# Altium schematic sheet ESP-TX.SchDoc
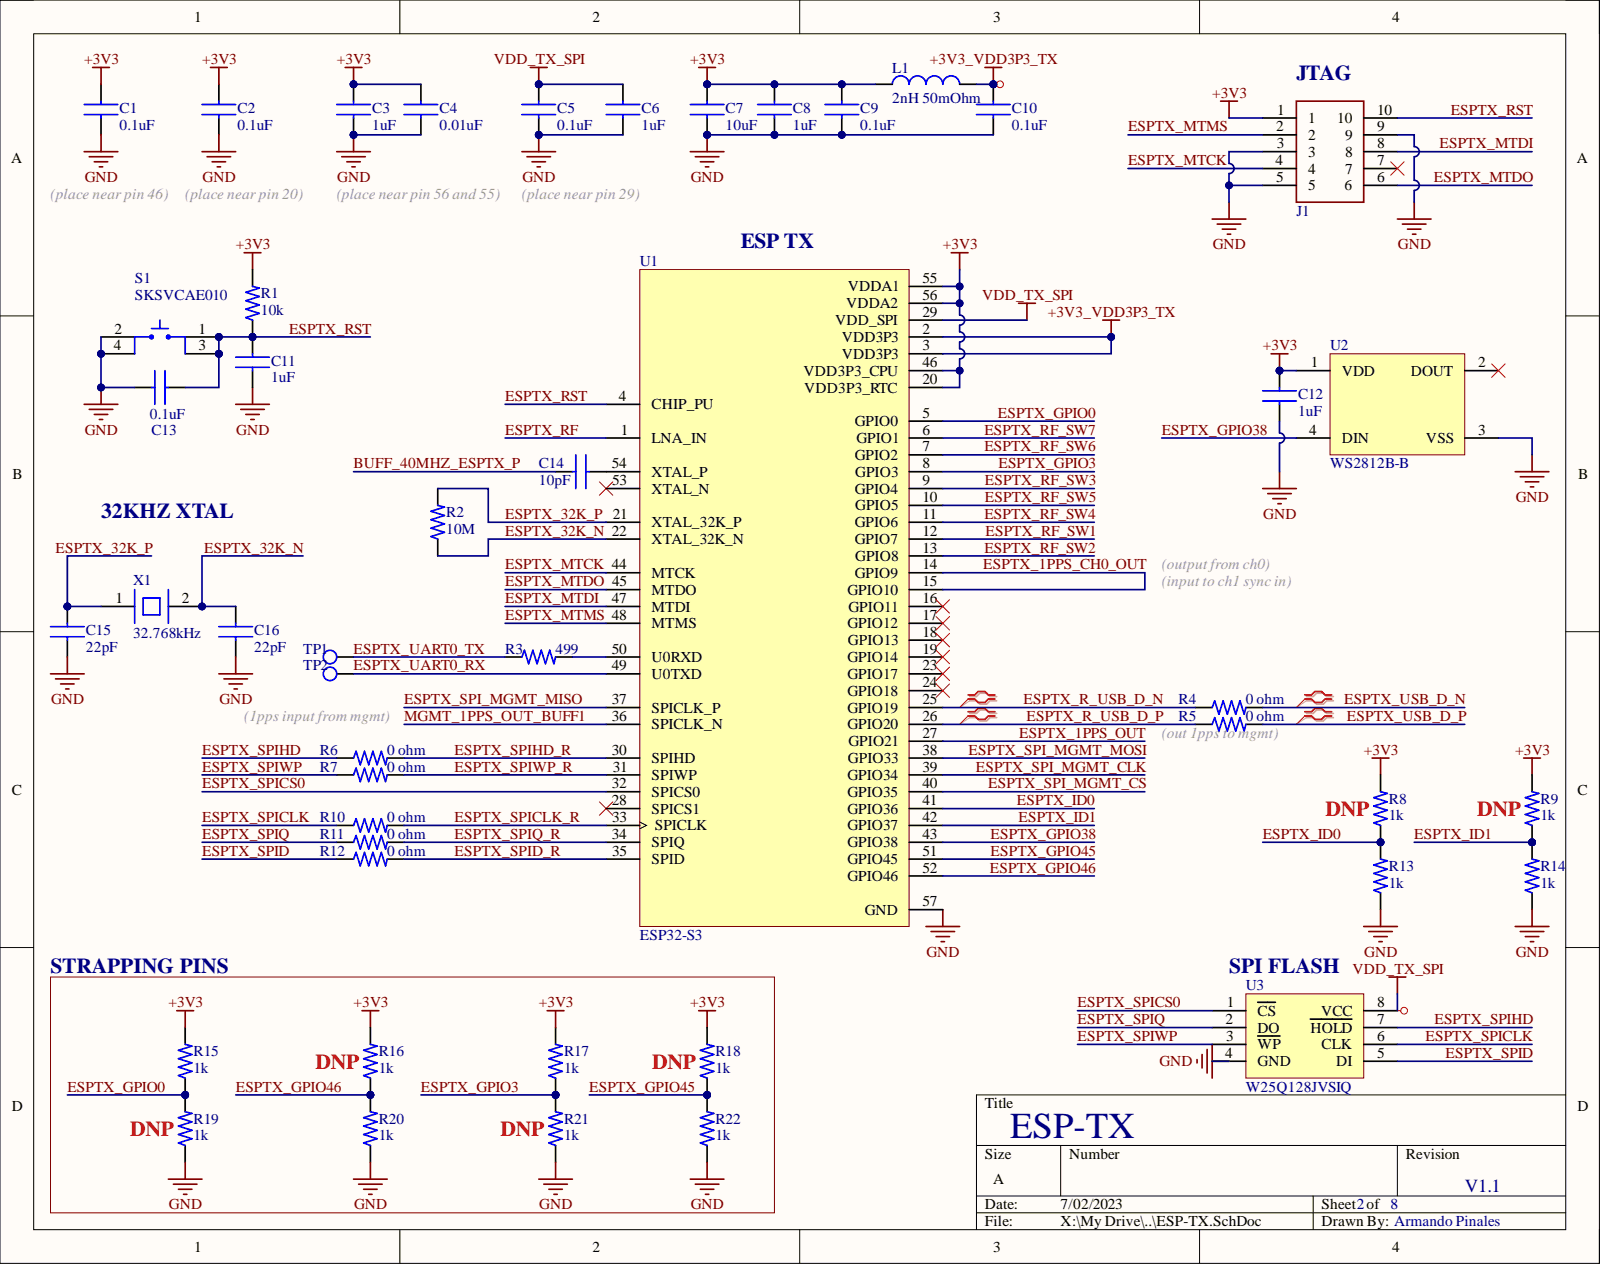
[diagram p0: ESP-TX.SchDoc]

## Parts
C1  0.1uF  [CAP_0402]
C2  0.1uF  [CAP_0402]
C3  1uF  [CAP_0402]
C4  0.01uF  [CAP_0402]
C5  0.1uF  [CAP_0402]
C6  1uF  [CAP_0402]
C7  10uF  [CAP_0402]
C8  1uF  [CAP_0402]
C9  0.1uF  [CAP_0402]
C10  0.1uF  [CAP_0402]
C11  1uF  [CAP_0402]
C12  1uF  [CAP_0402]
C13  0.1uF  [CAP_0402]
C14  10pF  [CAP_0402]
C15  22pF  [CAP_0402]
C16  22pF  [CAP_0402]
J1  CONN_TC2050-IDC  [CONN_TAG-CONNECT_TC2050-IDC]  (value not drawn)
L1  2nH 50mOhm  [IND_INDC1006X60N]
R1  10k  [RES_0402]
R2  10M  [RES_0402]
R3  499  [RES_0402]
R4  0 ohm  [RES_0402]
R5  0 ohm  [RES_0402]
R6  0 ohm  [RES_0402]
R7  0 ohm  [RES_0402]
R8  1k  [RES_0402]  (no BOM)
R9  1k  [RES_0402]  (no BOM)
R10  0 ohm  [RES_0402]
R11  0 ohm  [RES_0402]
R12  0 ohm  [RES_0402]
R13  1k  [RES_0402]
R14  1k  [RES_0402]
R15  1k  [RES_0402]
R16  1k  [RES_0402]  (no BOM)
R17  1k  [RES_0402]
R18  1k  [RES_0402]  (no BOM)
R19  1k  [RES_0402]  (no BOM)
R20  1k  [RES_0402]
R21  1k  [RES_0402]  (no BOM)
R22  1k  [RES_0402]
S1  SKSVCAE010  [SW_SKSVCAE010]
TP1  Test Point 1MM  [TP_PAD-1MM]  (value not drawn)  (no BOM)
TP2  Test Point 1MM  [TP_PAD-1MM]  (value not drawn)  (no BOM)
U1  ESP32-S3  [IC_ESP32-S3]
U2  WS2812B-B  [LED_WS2812B-B]
U3  W25Q128JVSIQ  [IC_W25Q128JVSIQ]
X1  32.768kHz  [XTAL_X321532768KGD2SI]

## Nets
+3V3: C1.2 C2.2 C3.2 C4.2 C7.2 C8.2 C9.2 C12.2 J1.1 L1.1 R1.2 R8.2 R9.2 R15.2 R16.2 R17.2 R18.2 U1.20(VDD3P3_RTC) U1.46(VDD3P3_CPU) U1.55(VDDA1) U1.56(VDDA2) U2.1(VDD)
+3V3_VDD3P3_TX: C10.2 L1.2 U1.2(VDD3P3) U1.3(VDD3P3)
GND: C1.1 C2.1 C3.1 C4.1 C5.1 C6.1 C7.1 C8.1 C9.1 C10.1 C11.1 C12.1 C13.1 C15.1 C16.1 J1.3 J1.5 J1.9 R13.1 R14.1 R19.1 R20.1 R21.1 R22.1 S1.2 S1.4 U1.57(GND) U2.3(VSS) U3.4(GND)
VDD_TX_SPI: C5.2 C6.2 U1.29(VDD_SPI) U3.8(VCC)
BUFF_40MHZ_ESPTX_P: C14.1
ESPTX_1PPS_CH0_OUT: U1.14(GPIO9) U1.15(GPIO10)
ESPTX_1PPS_OUT: U1.27(GPIO21)
ESPTX_32K_N: C16.2 R2.1 U1.22(XTAL_32K_N) X1.2
ESPTX_32K_P: C15.2 R2.2 U1.21(XTAL_32K_P) X1.1
ESPTX_GPIO0: R15.1 R19.2 U1.5(GPIO0)
ESPTX_GPIO3: R17.1 R21.2 U1.8(GPIO3)
ESPTX_GPIO38: U1.43(GPIO38) U2.4(DIN)
ESPTX_GPIO45: R18.1 R22.2 U1.51(GPIO45)
ESPTX_GPIO46: R16.1 R20.2 U1.52(GPIO46)
ESPTX_ID0: R8.1 R13.2 U1.41(GPIO36)
ESPTX_ID1: R9.1 R14.2 U1.42(GPIO37)
ESPTX_MTCK: J1.4 U1.44(MTCK)
ESPTX_MTDI: J1.8 U1.47(MTDI)
ESPTX_MTDO: J1.6 U1.45(MTDO)
ESPTX_MTMS: J1.2 U1.48(MTMS)
ESPTX_R_USB_D_N: R4.1 U1.25(GPIO19)
ESPTX_R_USB_D_P: R5.1 U1.26(GPIO20)
ESPTX_RF: U1.1(LNA_IN)
ESPTX_RF_SW1: U1.12(GPIO7)
ESPTX_RF_SW2: U1.13(GPIO8)
ESPTX_RF_SW3: U1.9(GPIO4)
ESPTX_RF_SW4: U1.11(GPIO6)
ESPTX_RF_SW5: U1.10(GPIO5)
ESPTX_RF_SW6: U1.7(GPIO2)
ESPTX_RF_SW7: U1.6(GPIO1)
ESPTX_RST: C11.2 C13.2 J1.10 R1.1 S1.1 S1.3 U1.4(CHIP_PU)
ESPTX_SPI_MGMT_CLK: U1.39(GPIO34)
ESPTX_SPI_MGMT_CS: U1.40(GPIO35)
ESPTX_SPI_MGMT_MISO: U1.37(SPICLK_P)
ESPTX_SPI_MGMT_MOSI: U1.38(GPIO33)
ESPTX_SPICLK: R10.1 U3.6(CLK)
ESPTX_SPICLK_R: R10.2 U1.33(SPICLK)
ESPTX_SPICS0: U1.32(SPICS0) U3.1(CS)
ESPTX_SPID: R12.1 U3.5(DI)
ESPTX_SPID_R: R12.2 U1.35(SPID)
ESPTX_SPIHD: R6.1 U3.7(HOLD)
ESPTX_SPIHD_R: R6.2 U1.30(SPIHD)
ESPTX_SPIQ: R11.1 U3.2(DO)
ESPTX_SPIQ_R: R11.2 U1.34(SPIQ)
ESPTX_SPIWP: R7.1 U3.3(WP)
ESPTX_SPIWP_R: R7.2 U1.31(SPIWP)
ESPTX_UART0_RX: TP2.1 U1.49(U0TXD)
ESPTX_UART0_TX: R3.1 TP1.1
ESPTX_USB_D_N: R4.2
ESPTX_USB_D_P: R5.2
MGMT_1PPS_OUT_BUFF1: U1.36(SPICLK_N)
NetC14_2: C14.2 U1.54(XTAL_P)
NetR3_2: R3.2 U1.50(U0RXD)

Not connected: J1 7; U1 16(GPIO11) 17(GPIO12) 18(GPIO13) 19(GPIO14) 23(GPIO17) 24(GPIO18) 28(SPICS1) 53(XTAL_N); U2 2(DOUT)

## Library data of the parts
C1 C2 C5 C9 C10 C13 = CAP_GCM155R71C104KA55J: Cap Ceramic 0.1uF 16V X7R 10% SMD 0402 125C Paper T/R; Manufacturer: Murata; Capacitance: 100nF; Case Code (Imperial): 0402; Case Code (Metric): 1005; Case/Package: 0402; Category: Ceramic Capacitors; Component Kind: Standard; ComponentLink1Description: https://datasheet.ciiva.com/11618/product-11618848.pdf?src-supplier=Avnet; ComponentLink1URL: https://datasheet.ciiva.com/11618/product-11618848.pdf?src-supplier=Avnet; ComponentLink2Description: https://datasheet.ciiva.com/16440/gcm155r71c104ka55j-16440393.pdf?src-supplier=Future+Electronics; ComponentLink2URL: https://datasheet.ciiva.com/16440/gcm155r71c104ka55j-16440393.pdf?src-supplier=Future+Electronics; ComponentLink3Description: https://datasheet.ciiva.com/29542/product-29542474.pdf?src-supplier=Digi-Key; ComponentLink3URL: https://datasheet.ciiva.com/29542/product-29542474.pdf?src-supplier=Digi-Key; ComponentLink4Description: https://datasheet.ciiva.com/29542/product-29542475.pdf?src-supplier=Digi-Key; ComponentLink4URL: https://datasheet.ciiva.com/29542/product-29542475.pdf?src-supplier=Digi-Key; Construction: Flat; Depth: 0.5mm; Dielectric: X7R; Height: 0.5mm; Lead Free: Lead Free; Length: 1mm; Manufacturer Part Number: GCM155R71C104KA55J; Max Operating Temperature: 125°C; Min Operating Temperature: -55°C; Mount: Surface Mount; Package Quantity: 1; Packaging: Tape and Reel; Pricing 1: 1=0.10000, 10=0.03500, 50=0.01920, 100=0.01560, 500=0.01116, 1000=0.00877, 2500=0.00798, 5000=0.00734 (USD); Radiation Hardening: No; Ratings: AEC-Q200; RoHS: Yes; Series: GCM; Stock 1: 10738061; Supplier 1: Digi-Key; Supplier Part Number 1: 490-7998-1-ND; Termination: SMD/SMT; Thickness: 0.022inch; Tolerance: 10%; Voltage Rating: 16V; Voltage Rating (DC): 16V; Width: 0.5mm; Library Name: LotusSound.IntLib
C3 C6 C8 C11 C12 = CAP_CL05A105KA5NQNC: Cap Ceramic 1uF 25V X5R 10% SMD 0402 85°C Cardboard T/R; Manufacturer: Samsung; Capacitance: 1uF; Case Code (Imperial): 0402; Case Code (Metric): 1005; Case/Package: 0402; Category: Ceramic Capacitors; Component Kind: Standard; ComponentLink1Description: https://datasheet.ciiva.com/3777/cl05a105ka5nqnc-3777709.pdf?src-supplier=Arrow+Electronics; ComponentLink1URL: https://datasheet.ciiva.com/3777/cl05a105ka5nqnc-3777709.pdf?src-supplier=Arrow+Electronics; ComponentLink2Description: https://datasheet.ciiva.com/25720/cl05a105ka5nqnc-25720771.pdf?src-supplier=Digi-Key; ComponentLink2URL: https://datasheet.ciiva.com/25720/cl05a105ka5nqnc-25720771.pdf?src-supplier=Digi-Key; ComponentLink3Description: https://datasheet.ciiva.com/29198/459147-da-01-en-vs-kondensator-0402-29198339.pdf?src-supplier=Conrad; ComponentLink3URL: https://datasheet.ciiva.com/29198/459147-da-01-en-vs-kondensator-0402-29198339.pdf?src-supplier=Conrad; Construction: Flat; Depth: 0.5mm; Dielectric: X5R; Height: 0.5mm; Lead Free: Lead Free; Length: 1mm; Manufacturer Part Number: CL05A105KA5NQNC; Material: Ceramic; Max Operating Temperature: 85°C; Min Operating Temperature: -55°C; Mount: Surface Mount; Package Quantity: 10000; Packaging: Tape and Reel; Pricing 1: 1=0.21000, 10=0.14900, 50=0.08460, 100=0.07000, 500=0.04910, 1000=0.04065, 2500=0.03784, 5000=0.03462 (USD); Radiation Hardening: No; RoHS: Yes; RoHS Compliant: Yes; Series: CL; Supplier 1: Digi-Key; Supplier Part Number 1: 1276-1445-6-ND; Thickness: 0.022inch; Tolerance: 10%; Voltage Rating: 25V; Width: 0.02inch; Library Name: LotusSound.IntLib
C4 = CAP_CC0402KRX7R9BB103: Cap Ceramic 0.01uF 50V X7R 10% Pad SMD 0402 125°C T/R; Manufacturer: Yageo; Capacitance: 10nF; Case Code (Imperial): 0402; Case Code (Metric): 1005; Case/Package: 0402; Category: Ceramic Capacitors; Component Kind: Standard; ComponentLink1Description: https://datasheet.ciiva.com/11788/0900766b8079c870-11788375.pdf?src-supplier=RSComponents; ComponentLink1URL: https://datasheet.ciiva.com/11788/0900766b8079c870-11788375.pdf?src-supplier=RSComponents; ComponentLink2Description: https://datasheet.ciiva.com/26862/getdatasheetpartid-138248-26862642.pdf?src-supplier=Verical; ComponentLink2URL: https://datasheet.ciiva.com/26862/getdatasheetpartid-138248-26862642.pdf?src-supplier=Verical; ComponentLink3Description: https://datasheet.ciiva.com/7978/1489800-7978093.pdf?src-supplier=Element14; ComponentLink3URL: https://datasheet.ciiva.com/7978/1489800-7978093.pdf?src-supplier=Element14; ComponentLink4Description: https://datasheet.ciiva.com/28450/1489800-28450377.pdf?src-supplier=Element14; ComponentLink4URL: https://datasheet.ciiva.com/28450/1489800-28450377.pdf?src-supplier=Element14; ComponentLink5Description: https://datasheet.ciiva.com/176/mlccorderingcode-176349.pdf?src-supplier=Digi-Key; ComponentLink5URL: https://datasheet.ciiva.com/176/mlccorderingcode-176349.pdf?src-supplier=Digi-Key; ComponentLink6Description: https://datasheet.ciiva.com/176/mlccorderingcode-176348.pdf?src-supplier=Digi-Key; ComponentLink6URL: https://datasheet.ciiva.com/176/mlccorderingcode-176348.pdf?src-supplier=Digi-Key; ComponentLink7Description: https://datasheet.ciiva.com/176/mlccorderingcode-176350.pdf?src-supplier=Digi-Key; ComponentLink7URL: https://datasheet.ciiva.com/176/mlccorderingcode-176350.pdf?src-supplier=Digi-Key; ComponentLink8Description: https://datasheet.ciiva.com/16625/upy-gphc-x7r-6-3v-to-50v-8-16625513.pdf?src-supplier=Avnet+Europe; ComponentLink8URL: https://datasheet.ciiva.com/16625/upy-gphc-x7r-6-3v-to-50v-8-16625513.pdf?src-supplier=Avnet+Europe; Depth: 0.5mm; Dielectric: X7R; Halogen Free: Halogen Free; Height: 0.5mm; Lead Free: Lead Free; Length: 1mm; Manufacturer Part Number: CC0402KRX7R9BB103; Max Operating Temperature: 125°C; Min Operating Temperature: -55°C; Mount: Surface Mount; Package Quantity: 1; Packaging: Tape and Reel; Pricing 1: 1=0.10000, 10=0.01800, 50=0.01000, 100=0.00820, 500=0.00588, 1000=0.00462, 2500=0.00420, 5000=0.00386 (USD); Radiation Hardening: No; REACH SVHC: No SVHC; Resistor Type: Ceramic; RoHS: Yes; RoHS Compliant: Yes; Series: CC; Stock 1: 2049089; Supplier 1: Digi-Key; Supplier Part Number 1: 311-1349-1-ND; Termination: SMD/SMT; Thickness: 0.022inch; Tolerance: 10%; Voltage: 50V; Voltage Rating: 50V; Voltage Rating (DC): 50V; Width: 0.5mm; Library Name: LotusSound.IntLib
C7 = CAP_CL05A106MQ5NUNC: Cap Ceramic 10uF 6.3V X5R 20% SMD 0402 85°C Paper T/R; Manufacturer: Samsung; Capacitance: 10uF; Case Code (Imperial): 0402; Case Code (Metric): 1005; Case/Package: 0402; Category: Ceramic Capacitors; Component Kind: Standard; ComponentLink1Description: https://datasheet.ciiva.com/16437/cl05a106mq5nunc-16437356.pdf?src-supplier=Future+Electronics; ComponentLink1URL: https://datasheet.ciiva.com/16437/cl05a106mq5nunc-16437356.pdf?src-supplier=Future+Electronics; ComponentLink2Description: https://datasheet.ciiva.com/26877/getdatasheetpartid-355289-26877841.pdf?src-supplier=Verical; ComponentLink2URL: https://datasheet.ciiva.com/26877/getdatasheetpartid-355289-26877841.pdf?src-supplier=Verical; Construction: Flat; Depth: 0.5mm; Dielectric: X5R; Height: 0.5mm; Length: 1mm; Manufacturer Part Number: CL05A106MQ5NUNC; Max Operating Temperature: 85°C; Min Operating Temperature: -55°C; Mount: Surface Mount; Package Quantity: 1; Packaging: Tape and Reel; Pricing 1: 1=0.27000, 10=0.18400, 50=0.11020, 100=0.09300, 500=0.06362, 1000=0.05629, 2500=0.05384, 5000=0.04894 (USD); Radiation Hardening: No; RoHS: Yes; Series: CL; Stock 1: 3345553; Supplier 1: Digi-Key; Supplier Part Number 1: 1276-1451-1-ND; Thickness: 0.028inch; Tolerance: 20%; Voltage Rating: 6.3V; Width: 0.02inch; Library Name: LotusSound.IntLib
C14 = CAP_CGA2B2NP01H100D050BA: Cap Ceramic 10pF 50V C0G 0.5pF Pad SMD 0402 150C Automotive T/R; Manufacturer: TDK; Case Code (Imperial): 0402; Case Code (Metric): 1005; Case/Package: 0402; Category: Ceramic Capacitors; Component Kind: Standard; ComponentLink1Description: http://www.farnell.com/datasheets/2628288.pdf?src-supplier=Farnell; ComponentLink1URL: http://www.farnell.com/datasheets/2628288.pdf?src-supplier=Farnell; ComponentLink2Description: http://www.mouser.com/ds/2/400/mlcc_automotive_hightemp_en-520039.pdf?src-supplier=Mouser; ComponentLink2URL: http://www.mouser.com/ds/2/400/mlcc_automotive_hightemp_en-520039.pdf?src-supplier=Mouser; ComponentLink3Description: http://product.tdk.com/en/catalog/datasheets/mlcc_automotive_hightemp_en.pdf?src-supplier=Avnet; ComponentLink3URL: http://product.tdk.com/en/catalog/datasheets/mlcc_automotive_hightemp_en.pdf?src-supplier=Avnet; ComponentLink4Description: https://product.tdk.com/info/en/documents/chara_sheet/CGA2B2NP01H100D050BA.pdf?src-supplier=Digi-Key; ComponentLink4URL: https://product.tdk.com/info/en/documents/chara_sheet/CGA2B2NP01H100D050BA.pdf?src-supplier=Digi-Key; ComponentLink5Description: http://www.farnell.com/datasheets/2628288.pdf?src-supplier=Element14; ComponentLink5URL: http://www.farnell.com/datasheets/2628288.pdf?src-supplier=Element14; ComponentLink6Description: http://www.farnell.com/datasheets/2628288.pdf?src-supplier=Newark; ComponentLink6URL: http://www.farnell.com/datasheets/2628288.pdf?src-supplier=Newark; Dielectric: C0G; Features: High Temperature; Height: 0.5mm; Lead Free: Lead Free; Length: 0.039inch; Manufacturer Part Number: CGA2B2NP01H100D050BA; Max Operating Temperature: 150°C; Min Operating Temperature: -55°C; Mounting Technology: Surface Mount; Package Quantity: 1; Packaging: Tape and Reel; Pricing 1: 1=0.1, 10=0.072, 50=0.0408, 100=0.0338, 500=0.02372, 1000=0.01964, 2500=0.01828, 5000=0.01672 (USD); Ratings: AEC-Q200; RoHS: Yes; RoHS Compliant: Yes; Series: CGA; Stock 1: 696060; Supplier 1: Digi-Key; Supplier Part Number 1: 445-12120-1-ND; Termination: SMD/SMT; Thickness: 0.022inch; Value: 10pF; Voltage Rating: 50V; Voltage Rating (DC): 50V; Weight: 2.3E-05oz; Width: 0.02inch; Library Name: LotusSound.IntLib
C15 C16 = CAP_CC0402JRNPO9BN220: 0402 22 pF 50 V ±5 % Tolerance NP0 SMT Multilayer Ceramic Capacitor; Manufacturer: Yageo; Dielectric: C0G; Insulation Resistance: 100GR; Temperature Coefficient: 30ppm/°C; Tolerance: 5%; Voltage: 50V; Capacitance: 22pF; Case Code (Imperial): 0402; Case Code (Metric): 1005; Case/Package: 0402; Category: Ceramic Capacitors; Component Kind: Standard; ComponentLink1Description: https://datasheet.ciiva.com/143/mlccorderingcode-143986.pdf?src-supplier=Digi-Key; ComponentLink1URL: https://datasheet.ciiva.com/143/mlccorderingcode-143986.pdf?src-supplier=Digi-Key; ComponentLink2Description: https://datasheet.ciiva.com/143/mlccorderingcode-143987.pdf?src-supplier=Digi-Key; ComponentLink2URL: https://datasheet.ciiva.com/143/mlccorderingcode-143987.pdf?src-supplier=Digi-Key; ComponentLink3Description: https://datasheet.ciiva.com/143/mlccorderingcode-143988.pdf?src-supplier=Digi-Key; ComponentLink3URL: https://datasheet.ciiva.com/143/mlccorderingcode-143988.pdf?src-supplier=Digi-Key; ComponentLink4Description: https://datasheet.ciiva.com/5789/1443161-5789509.pdf?src-supplier=Farnell; ComponentLink4URL: https://datasheet.ciiva.com/5789/1443161-5789509.pdf?src-supplier=Farnell; ComponentLink5Description: https://datasheet.ciiva.com/5425/upy-gp-np0-16v-to-50v-8-5425483.pdf?src-supplier=Mouser; ComponentLink5URL: https://datasheet.ciiva.com/5425/upy-gp-np0-16v-to-50v-8-5425483.pdf?src-supplier=Mouser; ComponentLink6Description: https://datasheet.ciiva.com/15189/getdatasheetpartid-370074-15189117.pdf?src-supplier=Verical; ComponentLink6URL: https://datasheet.ciiva.com/15189/getdatasheetpartid-370074-15189117.pdf?src-supplier=Verical; ComponentLink7Description: https://datasheet.ciiva.com/7656/1132289-7656615.pdf?src-supplier=Element14; ComponentLink7URL: https://datasheet.ciiva.com/7656/1132289-7656615.pdf?src-supplier=Element14; ComponentLink8Description: https://datasheet.ciiva.com/16625/upy-gp-np0-16v-to-50v-8-16625509.pdf?src-supplier=Avnet+Europe; ComponentLink8URL: https://datasheet.ciiva.com/16625/upy-gp-np0-16v-to-50v-8-16625509.pdf?src-supplier=Avnet+Europe; ComponentLink9Description: https://datasheet.ciiva.com/8033/1443161-8033605.pdf?src-supplier=Element14; ComponentLink9URL: https://datasheet.ciiva.com/8033/1443161-8033605.pdf?src-supplier=Element14; ComponentLink10Description: https://datasheet.ciiva.com/8033/1443161-8033606.pdf?src-supplier=Element14; ComponentLink10URL: https://datasheet.ciiva.com/8033/1443161-8033606.pdf?src-supplier=Element14; ComponentLink11Description: https://datasheet.ciiva.com/8033/1443161-8033607.pdf?src-supplier=Element14; ComponentLink11URL: https://datasheet.ciiva.com/8033/1443161-8033607.pdf?src-supplier=Element14; Depth: 0.5mm; Dielectric Material: Ceramic; Height: 0.5mm; Lead Free: Lead Free; Length: 1mm; Manufacturer Part Number: CC0402JRNPO9BN220; Max Operating Temperature: 125°C; Min Operating Temperature: -55°C; Mount: Surface Mount; Number of Pins: 2; Package Quantity: 1; Packaging: Tape and Reel; Pricing 1: 1=0.10000, 10=0.02200, 50=0.01180, 100=0.00960, 500=0.00688, 1000=0.00541, 2500=0.00492, 5000=0.00452 (USD); Radiation Hardening: No; REACH SVHC: No SVHC; Resistor Type: Ceramic; RoHS: Yes; RoHS Compliant: Yes; Series: CC; Stock 1: 1052; Supplier 1: Digi-Key; Supplier Part Number 1: 311-1018-1-ND; Termination: SMD/SMT; Thickness: 0.022inch; Voltage Rating: 50V; Voltage Rating (DC): 50V; Width: 0.02inch; Library Name: LotusSound.IntLib
J1 = CONN_TC2050-IDC: Cbl Plug-Of-Nails 10-Pin w/Legs; MF: {'manufacturer': u'Tag-Connect LLC'}; Availability: Unavailable; Component Kind: Standard; MP: TC2050-IDC; Package: None; Price: None; Library Name: LotusSound.SchLib
L1 = IND_MHQ1005P2N0STD25: FIXED IND 2NH 1A 50 MOHM SMD; MF: {'manufacturer': u'TDK'}; Availability: Unavailable; Component Kind: Standard; MP: MHQ1005P2N0STD25; Package: None; Price: None; Library Name: LotusSound.IntLib
R1 = RES_AC0402FR-0710KL: RES SMD 10K OHM 1% 1/16W 0402; Manufacturer: Yageo; Max Power Dissipation: 63mW; Power Rating: 62.5mW; Resistance: 10kR; Temperature Coefficient: 100ppm/°C; Voltage Rating: 50V; Case Code (Imperial): 0402; Case Code (Metric): 1005; Case/Package: 0402; Category: Chip SMD Resistors; Component Kind: Standard; ComponentLink1Description: https://datasheet.ciiva.com/12656/pyu-ac-51-rohs-l-2-12656634.pdf?src-supplier=Mouser; ComponentLink1URL: https://datasheet.ciiva.com/12656/pyu-ac-51-rohs-l-2-12656634.pdf?src-supplier=Mouser; Construction: Rectangular; Depth: 0.5mm; Features: Moisture Resistant,Automotive AEC-Q200; Height: 0.015inch; Lead Free: Lead Free; Length: 0.039inch; Manufacturer Part Number: AC0402FR-0710KL; Max Operating Temperature: 155°C; Military Standard: Not; Min Operating Temperature: -55°C; Number of Terminations: 2; Packaging: Tape and Reel; Pricing 1: 1=0.10000, 10=0.02200, 100=0.00900, 1000=0.00402, 2500=0.00349, 5000=0.00288 (USD); Resistor Type: Thick Film; RoHS: Yes; RoHS Compliant: Yes; Series: AC; Size Code: 0402; Stock 1: 2860; Supplier 1: Digi-Key; Supplier Part Number 1: YAG3436CT-ND; Termination: SMD/SMT; Tolerance: 1%; Width: 0.02inch; Library Name: LotusSound.IntLib
R2 = RES_CRGCQ0402J10M: Res Thick Film 0402 10M Ohm 5% 0.063W(1/16W) ±100ppm/C Pad SMD Automotive T/R; Manufacturer: TE Connectivity; Max Power Dissipation: 63mW; Temperature Coefficient: 100ppm/°C; Value: 10MR; Case/Package: 0402; Category: Chip SMD Resistors; Component Kind: Standard; ComponentLink1Description: https://www.te.com/commerce/DocumentDelivery/DDEController?Action=srchrtrv&amp;DocNm=1773204-3&amp;DocType=DS&amp;DocLang=English&src-supplier=Digi-Key; ComponentLink1URL: https://www.te.com/commerce/DocumentDelivery/DDEController?Action=srchrtrv&amp;DocNm=1773204-3&amp;DocType=DS&amp;DocLang=English&src-supplier=Digi-Key; Features: Moisture Resistant,Automotive AEC-Q200; Manufacturer Part Number: CRGCQ0402J10M; Max Operating Temperature: 155°C; Min Operating Temperature: -55°C; Number of Terminations: 2; Pricing 1: 1=0.1, 10=0.018, 50=0.01, 100=0.0073, 500=0.00448, 1000=0.00329, 5000=0.00236 (USD); Ratings: AEC-Q200; RoHS: Yes; RoHS Compliant: Yes; Series: CRGCQ; Stock 1: 23325092; Supplier 1: Digi-Key; Supplier Part Number 1: A130072CT-ND; Tolerance: 5%; Library Name: LotusSound.IntLib
R3 = RES_RC0402FR-07499RL: Res General Purpose Thick Film 0402 499 Ohm 1% 1/16W ±100ppm/°C Molded SMD Paper T/R; Manufacturer: Yageo; Max Power Dissipation: 63mW; Power Rating: 62.5mW; Value: 499R; Voltage Rating: 50V; Case Code (Imperial): 0402; Case Code (Metric): 1005; Case/Package: 0402; Category: Chip SMD Resistors; Component Kind: Standard; ComponentLink1Description: https://datasheet.ciiva.com/2453/pyu-r-int-thick-7-2453539.pdf?src-supplier=Digi-Key; ComponentLink1URL: https://datasheet.ciiva.com/2453/pyu-r-int-thick-7-2453539.pdf?src-supplier=Digi-Key; ComponentLink2Description: https://datasheet.ciiva.com/2453/pyu-r-int-thick-7-2453540.pdf?src-supplier=Digi-Key; ComponentLink2URL: https://datasheet.ciiva.com/2453/pyu-r-int-thick-7-2453540.pdf?src-supplier=Digi-Key; ComponentLink3Description: https://datasheet.ciiva.com/2453/pyu-r-int-thick-7-2453538.pdf?src-supplier=Digi-Key; ComponentLink3URL: https://datasheet.ciiva.com/2453/pyu-r-int-thick-7-2453538.pdf?src-supplier=Digi-Key; Construction: Rectangular; Depth: 0.5mm; Features: Moisture Resistant; Height: 0.016inch; Lead Free: Lead Free; Length: 0.039inch; Manufacturer Part Number: RC0402FR-07499RL; Max Operating Temperature: 155°C; Military Standard: Not; Min Operating Temperature: -55°C; Number of Terminations: 2; Package Quantity: 1; Packaging: Tape and Reel; Pricing 1: 1=0.1, 10=0.015, 50=0.009, 100=0.0074, 500=0.00492, 1000=0.00421, 5000=0.003 (USD); Reach SVHC Compliant: No; Resistance Tolerance: 1%; Resistor Type: Thick Film; RoHS: Yes; RoHS Compliant: Yes; Series: RC; Stock 1: 3392494; Supplier 1: Digi-Key; Supplier Part Number 1: 311-499LRCT-ND; Temperature Coefficient: 100ppm/°C; Termination: SMD/SMT; Tolerance: 1%; Voltage Rating (DC): 50V; Width: 0.02inch; Library Name: LotusSound.IntLib
R4-R7 R10-R12 = RES_RC0402JR-070RL: RES SMD 0 OHM JUMPER 1/16W 0402; Manufacturer: Yageo; Max Power Dissipation: 63mW; Power Rating: 62.5mW; Resistance Tolerance: 5%; Temperature Coefficient: 200ppm/°C; Value: 0mR; Voltage Rating: 50V; Case Code (Imperial): 0402; Case Code (Metric): 1005; Case/Package: 0402; Category: Chip SMD Resistors; Component Kind: Standard; ComponentLink1Description: https://datasheet.ciiva.com/2452/pyu-rc0402-51-rohs-l-6-2452608.pdf?src-supplier=Digi-Key; ComponentLink1URL: https://datasheet.ciiva.com/2452/pyu-rc0402-51-rohs-l-6-2452608.pdf?src-supplier=Digi-Key; ComponentLink2Description: https://datasheet.ciiva.com/7983/1018594-7983535.pdf?src-supplier=Element14; ComponentLink2URL: https://datasheet.ciiva.com/7983/1018594-7983535.pdf?src-supplier=Element14; ComponentLink3Description: https://datasheet.ciiva.com/22747/1018594-22747515.pdf?src-supplier=Element14; ComponentLink3URL: https://datasheet.ciiva.com/22747/1018594-22747515.pdf?src-supplier=Element14; ComponentLink4Description: https://datasheet.ciiva.com/2452/pyu-r-int-thick-7-2452609.pdf?src-supplier=Digi-Key; ComponentLink4URL: https://datasheet.ciiva.com/2452/pyu-r-int-thick-7-2452609.pdf?src-supplier=Digi-Key; ComponentLink5Description: https://datasheet.ciiva.com/2452/pyu-r-int-thick-7-2452610.pdf?src-supplier=Digi-Key; ComponentLink5URL: https://datasheet.ciiva.com/2452/pyu-r-int-thick-7-2452610.pdf?src-supplier=Digi-Key; ComponentLink6Description: https://datasheet.ciiva.com/8896/pyu-rc0402-51-rohs-l-6-8896201.pdf?src-supplier=Avnet; ComponentLink6URL: https://datasheet.ciiva.com/8896/pyu-rc0402-51-rohs-l-6-8896201.pdf?src-supplier=Avnet; ComponentLink7Description: https://datasheet.ciiva.com/15189/getdatasheetpartid-326379-15189533.pdf?src-supplier=Verical; ComponentLink7URL: https://datasheet.ciiva.com/15189/getdatasheetpartid-326379-15189533.pdf?src-supplier=Verical; Construction: Rectangular; Contact Plating: Tin,Matte; Depth: 0.5mm; Features: Moisture Resistant; Height: 0.016inch; Lead Free: Lead Free; Length: 1mm; Manufacturer Part Number: RC0402JR-070RL; Max Operating Temperature: 155°C; Military Standard: Not; Min Operating Temperature: -55°C; Number of Terminations: 2; Package Quantity: 1; Packaging: Tape and Reel; Pricing 1: 1=0.10000, 10=0.01200, 25=0.00840, 50=0.00640, 100=0.00480, 250=0.00364, 500=0.00290, 1000=0.00214, 5000=0.00154 (USD); Radiation Hardening: No; Resistor Type: Thick Film; RoHS: Yes; RoHS Compliant: Yes; Series: RC; Stock 1: 33185339; Supplier 1: Digi-Key; Supplier Part Number 1: 311-0.0JRCT-ND; Technology: THICK FILM; Termination: SMD/SMT; Tolerance: 5%; Width: 0.02inch; Library Name: LotusSound.IntLib
R8 R9 R13-R22 = RES_RC0402JR-071KL: Res General Purpose Thick Film 0402 1K Ohm 5% 1/16W ±100ppm/°C Molded SMD Paper T/R; Manufacturer: Yageo; Max Power Dissipation: 63mW; Power Rating: 62.5mW; Resistance: 1kR; Voltage Rating: 50V; Case Code (Imperial): 0402; Case Code (Metric): 1005; Case/Package: 0402; Category: Chip SMD Resistors; ComponentLink1Description: https://datasheet.ciiva.com/2452/pyu-r-int-thick-7-2452755.pdf?src-supplier=Digi-Key; ComponentLink1URL: https://datasheet.ciiva.com/2452/pyu-r-int-thick-7-2452755.pdf?src-supplier=Digi-Key; ComponentLink2Description: https://datasheet.ciiva.com/2452/pyu-r-int-thick-7-2452756.pdf?src-supplier=Digi-Key; ComponentLink2URL: https://datasheet.ciiva.com/2452/pyu-r-int-thick-7-2452756.pdf?src-supplier=Digi-Key; ComponentLink3Description: https://datasheet.ciiva.com/2452/pyu-r-int-thick-7-2452757.pdf?src-supplier=Digi-Key; ComponentLink3URL: https://datasheet.ciiva.com/2452/pyu-r-int-thick-7-2452757.pdf?src-supplier=Digi-Key; ComponentLink4Description: https://datasheet.ciiva.com/17081/pyu-rc0402-51-rohs-l-6-r-17081438.pdf?src-supplier=Future+Electronics; ComponentLink4URL: https://datasheet.ciiva.com/17081/pyu-rc0402-51-rohs-l-6-r-17081438.pdf?src-supplier=Future+Electronics; ComponentLink5Description: https://datasheet.ciiva.com/7850/1018594-7850170.pdf?src-supplier=Element14; ComponentLink5URL: https://datasheet.ciiva.com/7850/1018594-7850170.pdf?src-supplier=Element14; ComponentLink6Description: https://datasheet.ciiva.com/7850/1018594-7850171.pdf?src-supplier=Element14; ComponentLink6URL: https://datasheet.ciiva.com/7850/1018594-7850171.pdf?src-supplier=Element14; ComponentLink7Description: https://datasheet.ciiva.com/7983/1018594-7983558.pdf?src-supplier=Element14; ComponentLink7URL: https://datasheet.ciiva.com/7983/1018594-7983558.pdf?src-supplier=Element14; Construction: Rectangular; Depth: 0.5mm; Features: Moisture Resistant; Height: 0.016inch; Lead Free: Lead Free; Length: 0.039inch; Manufacturer Part Number: RC0402JR-071KL; Max Operating Temperature: 155°C; Military Standard: Not; Min Operating Temperature: -55°C; Number of Terminations: 2; Package Quantity: 1; Packaging: Tape and Reel; Pricing 1: 1=0.10000, 10=0.01300, 100=0.00540, 1000=0.00244, 2500=0.00212, 5000=0.00175 (USD); REACH SVHC: No SVHC; Resistance Tolerance: 5%; Resistor Type: Thick Film; RoHS: Yes; RoHS Compliant: Yes; Series: RC; Size Code: 0402; Stock 1: 1442131; Supplier 1: Digi-Key; Supplier Part Number 1: 311-1.0KJRCT-ND; Temperature Coefficient: 100ppm/°C; Termination: SMD/SMT; Tolerance: 5%; Width: 0.02inch; Library Name: LotusSound.IntLib
  R13-R15 R17 R20 R22: Component Kind: Standard
S1 = SW_SKSVCAE010: 2.8x1.9mm Compact Type with Projection Surface Mount SKSV Series Tact Switch; Manufacturer: Alps Electric; Category: Tactile Switches; Component Kind: Standard; ComponentLink1Description: http://www.mouser.com/ds/2/15/ALPS_SKSVCAE010-463040.pdf?src-supplier=Mouser; ComponentLink1URL: http://www.mouser.com/ds/2/15/ALPS_SKSVCAE010-463040.pdf?src-supplier=Mouser; Manufacturer Part Number: SKSVCAE010; Pricing 1: 1=0.54000, 10=0.54000, 50=0.43600, 100=0.42100, 1000=0.37500, 10000=0.36200 (USD); RoHS: Yes; RoHS Compliant: Yes; Stock 1: 17441; Supplier 1: Mouser; Supplier Part Number 1: 688-SKSVCAE010; Library Name: LotusSound.SchLib
TP1 TP2 = TP_PAD-1MM: Library Name: LotusSound.IntLib
U1 = IC_ESP32-S3: IC RF TxRx + MCU Bluetooth, WiFi 802.11b/g/n, Bluetooth v5.0 2.412GHz ~ 2.484GHz 56-VFQFN Exposed Pad; MF: Espressif Systems; Availability: In Stock; Check_prices: https://www.snapeda.com/parts/ESP32-S3/Espressif+Systems/view-part/?ref=eda; Component Kind: Standard; MP: ESP32-S3; Package: None; Price: None; Purchase-URL: https://pricing.snapeda.com/search/part/ESP32-S3/?ref=eda; SnapEDA_Link: https://www.snapeda.com/parts/ESP32-S3/Espressif+Systems/view-part/?ref=snap; Supplier 1: LCSC; Supplier Part Number 1: C2913192; Library Name: LotusSound.SchLib
U2 = LED_WS2812B-B: Intelligent control LED integrated light source; Manufacturer: WORLDSEMI; Manufacturer Part Number: WS2812B-B/W; Availability: Unavailable; Category: Uncategorized; Component Kind: Standard; MP: WS2812B-B; Package: None; Price: None; Pricing 1: 5=0.0923, 50=0.0756, 150=0.067, 1000=0.06, 2000=0.0575, 5000=0.0558 (USD); RoHS: No; Stock 1: 70735; Supplier 1: LCSC; Supplier Part Number 1: C114586; Library Name: LotusSound.IntLib
U3 = IC_W25Q128JVSIQ: NOR Flash Serial (SPI, Dual SPI, Quad SPI) 3.3V 128M-bit 16M x 8 6ns 8-Pin SOIC Tube; Manufacturer: Winbond; Frequency: 133MHz; Memory Format: Non-Volatile,FLASH; Memory Size: 128Mb; Case/Package: SOIC; Category: Flash; Component Kind: Standard; ComponentLink1Description: http://www.winbond.com/resource-files/w25q128jv%20revf%2003272018%20plus.pdf?src-supplier=Digi-Key; ComponentLink1URL: http://www.winbond.com/resource-files/w25q128jv%20revf%2003272018%20plus.pdf?src-supplier=Digi-Key; Manufacturer Part Number: W25Q128JVSIQ; Max Operating Temperature: 85°C; Min Operating Temperature: -40°C; Mounting Technology: Surface Mount; Packaging: Tray; Pricing 1: 1=1.71, 10=1.562, 25=1.52, 50=1.5124, 100=1.3519, 250=1.348, 500=1.32762, 1000=1.27127, 5000=1.18359 (USD); RoHS: Yes; RoHS Compliant: Yes; Series: SpiFlash®; Stock 1: 154352; Supplier 1: Digi-Key; Supplier Part Number 1: W25Q128JVSIQ-ND; Library Name: LotusSound.IntLib
X1 = XTAL_X321532768KGD2SI: 贴片无源晶振 32.768KHZ 12.5PF ±20ppm –40~+85 ℃; Manufacturer: YXC; Component Kind: Standard; Manufacturer Part Number: X321532768KGD2SI; RoHS: No; Supplier 1: LCSC; Supplier Part Number 1: C620155; Library Name: LotusSound.IntLib
